# Altium schematic sheet ECONTROLmini.SchDoc(ECONTROLmini)
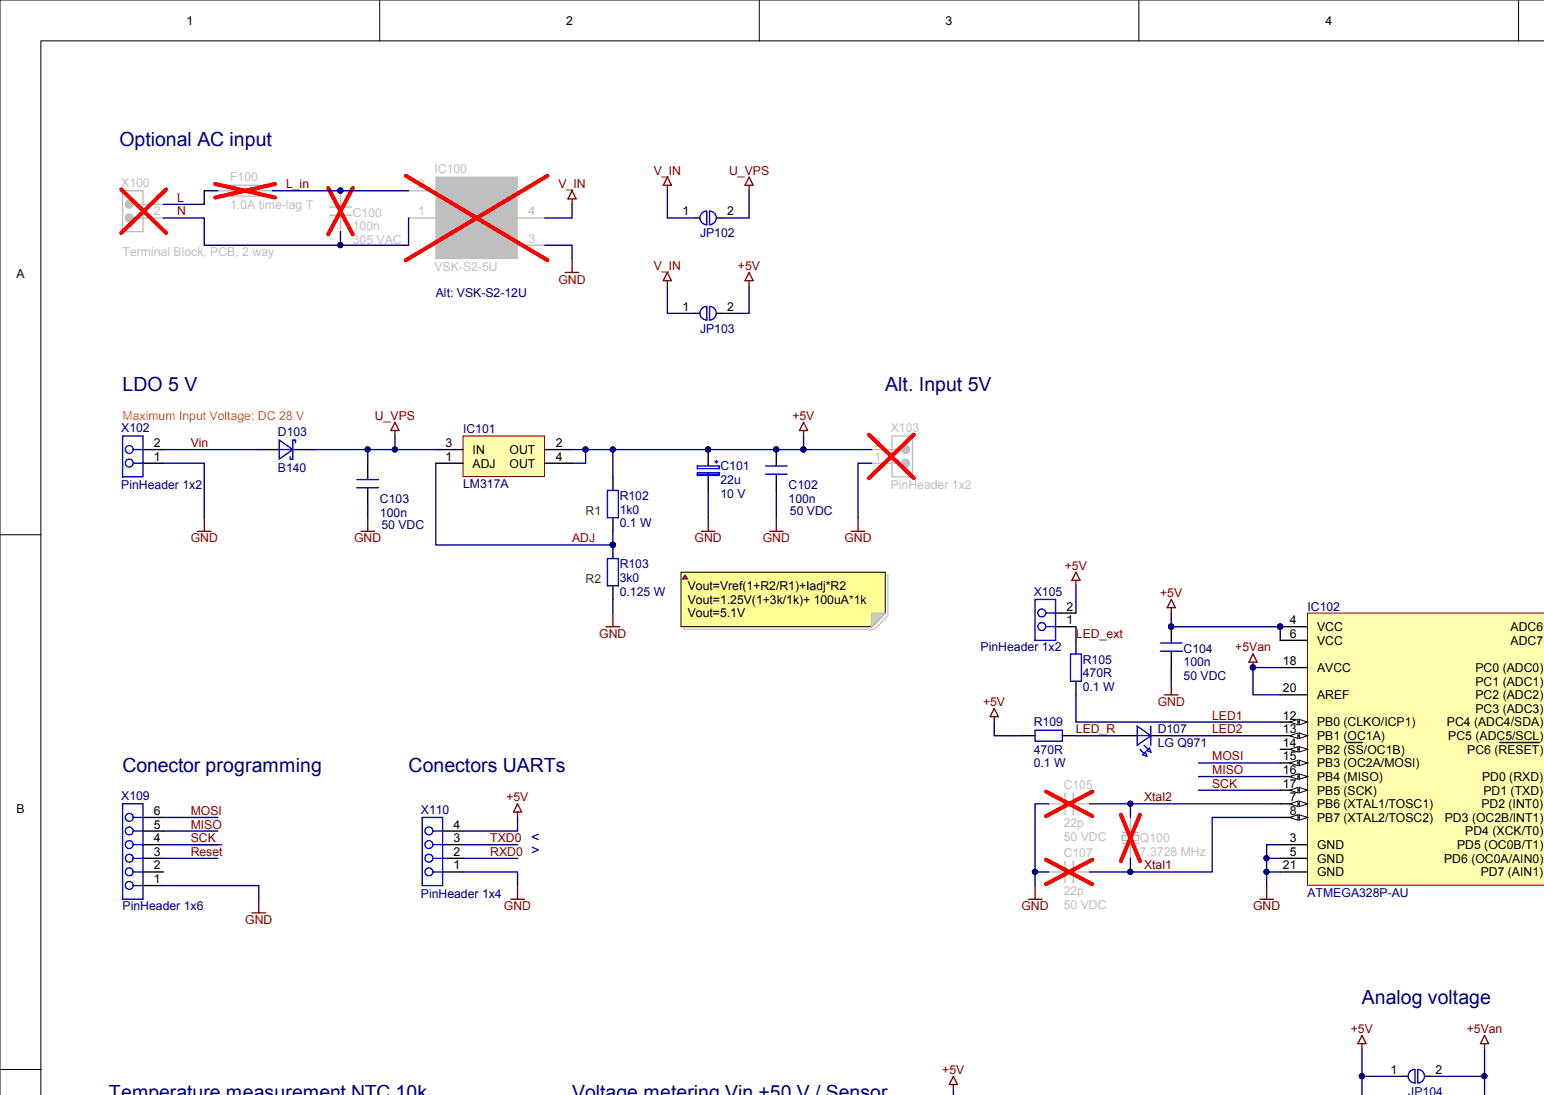
[diagram p0: ECONTROLmini.SchDoc, top left]
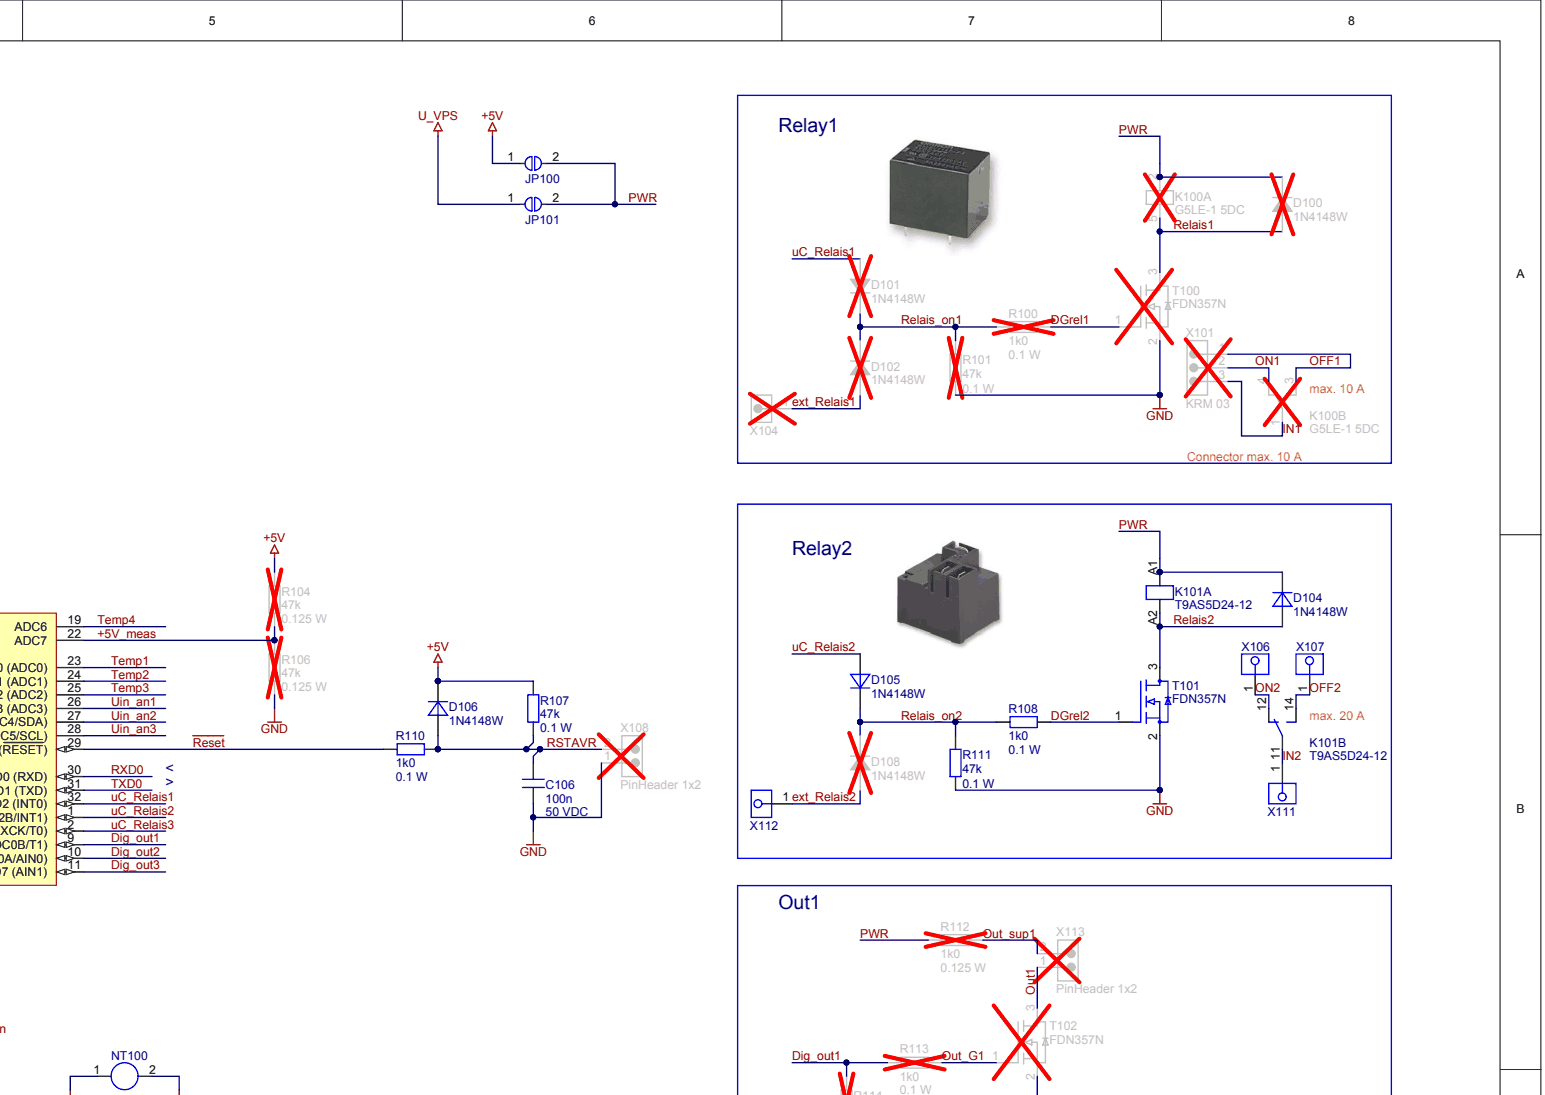
[diagram p1: ECONTROLmini.SchDoc, top right]
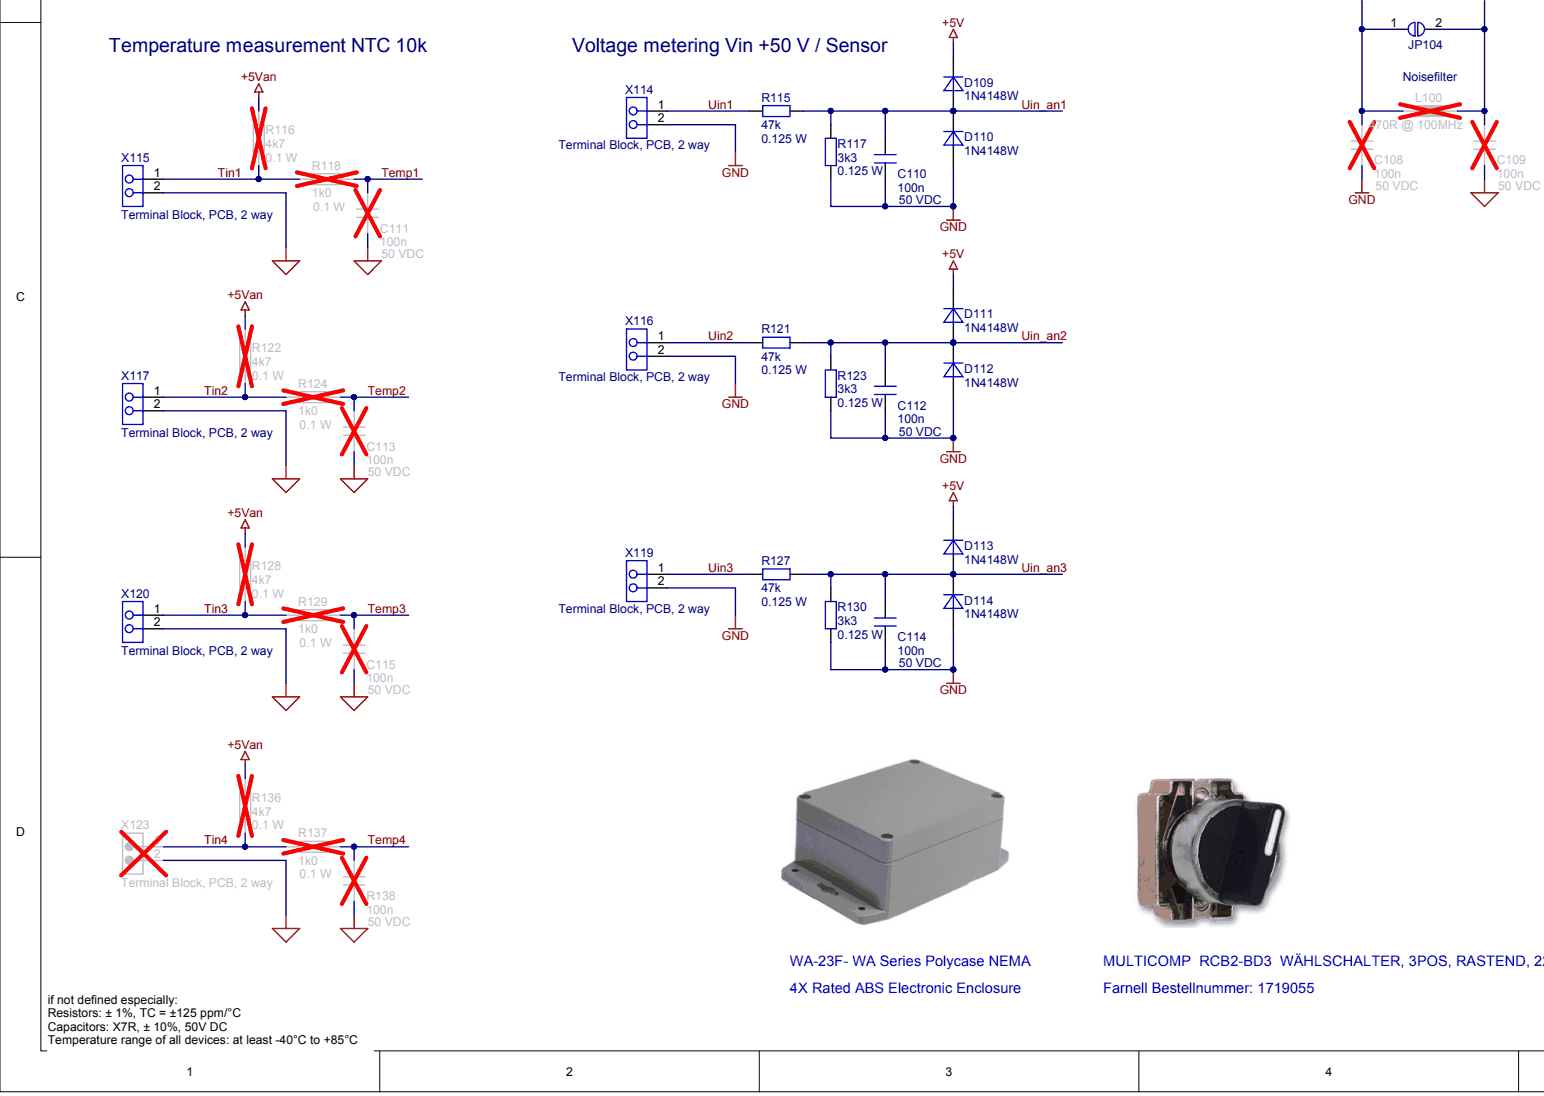
[diagram p2: ECONTROLmini.SchDoc, bottom left]
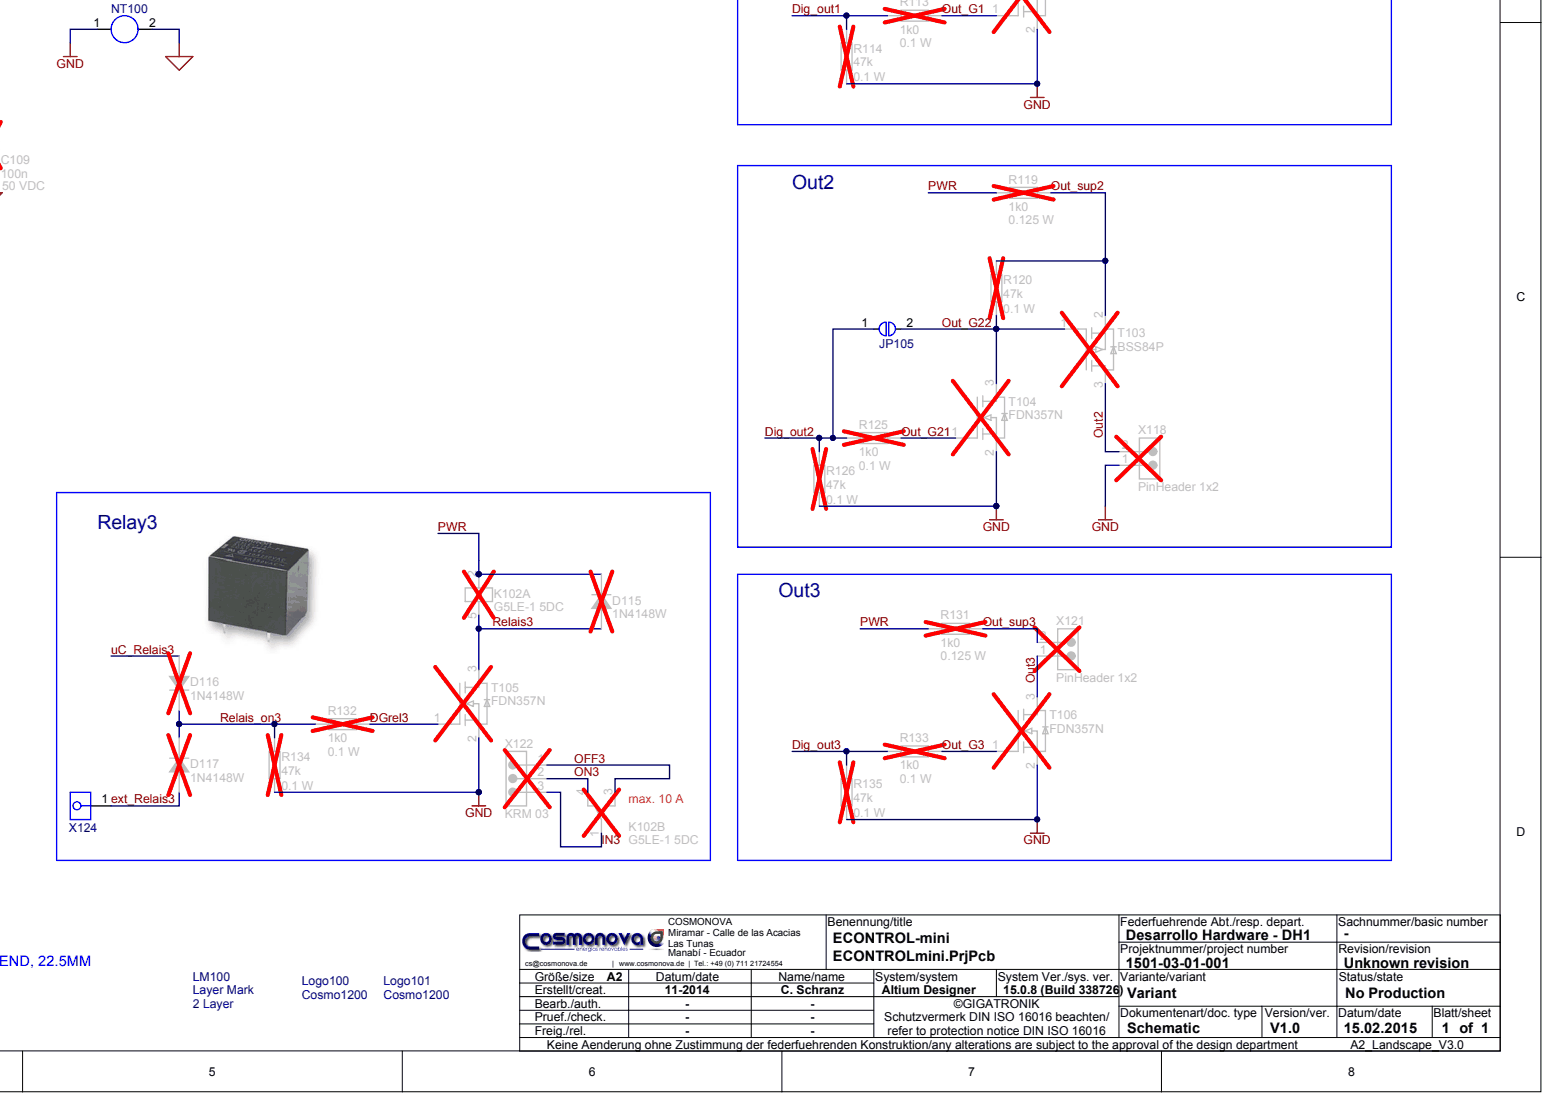
[diagram p3: ECONTROLmini.SchDoc, bottom right]

## Parts
C100  100n  [Capacitor_Epcos_MKP_B32922]
C101  22u  Voltage: 10 V  [CSMB]
C102  100n  Voltage: 50 VDC  [C0603]
C103  100n  Voltage: 50 VDC  [C0603]
C104  100n  Voltage: 50 VDC  [C0603]
C105  22p  [C0603]
C106  100n  Voltage: 50 VDC  [C0603]
C107  22p  Voltage: 50 VDC  [C0603]
C108  100n  Voltage: 50 VDC  [C0603]
C109  100n  Voltage: 50 VDC  [C0603]
C110  100n  Voltage: 50 VDC  [C0603]
C111  100n  Voltage: 50 VDC  [C0603]
C112  100n  Voltage: 50 VDC  [C0603]
C113  100n  Voltage: 50 VDC  [C0603]
C114  100n  Voltage: 50 VDC  [C0603]
C115  100n  Voltage: 50 VDC  [C0603]
D100  1N4148W  [SOD-123]
D101  1N4148W  [SOD-123]
D102  1N4148W  [SOD-123]
D103  B140  [SMA_DO-214AC]
D104  1N4148W  [SOD-123]
D105  1N4148W  [SOD-123]
D106  1N4148W  [SOD-123]
D107  LG Q971  [LED0603G]
D108  1N4148W  [SOD-123]
D109  1N4148W  [SOD-123]
D110  1N4148W  [SOD-123]
D111  1N4148W  [SOD-123]
D112  1N4148W  [SOD-123]
D113  1N4148W  [SOD-123]
D114  1N4148W  [SOD-123]
D115  1N4148W  [SOD-123]
D116  1N4148W  [SOD-123]
D117  1N4148W  [SOD-123]
F100  1.0A time-lag T  [Fuse_5x20]
IC100  VSK-S2-5U  [CUIInc_VSK-S2]
IC101  LM317A  [SOT-223]
IC102  ATMEGA328P-AU  [TQFP-32_0.8mm]
JP100  Solder Jumper  [Jumper_solder_x2_2mm]  (value not drawn)  (no BOM)
JP101  Solder Jumper  [Jumper_solder_x2_2mm]  (value not drawn)  (no BOM)
JP102  Solder Jumper  [Jumper_solder_x2_2mm]  (value not drawn)  (no BOM)
JP103  Solder Jumper  [Jumper_solder_x2_2mm]  (value not drawn)  (no BOM)
JP104  Solder Jumper  [Jumper_solder_x2_2mm]  (value not drawn)  (no BOM)
JP105  Solder Jumper  [Jumper_solder_x2_2mm]  (value not drawn)  (no BOM)
K100  G5LE-1 5DC  [Relay_SPDT]  (parts A,B)
K101  T9AS5D24-12  [Relay_TE_T9A]  (parts A,B)
K102  G5LE-1 5DC  [Relay_SPDT]  (parts A,B)
L100  470R @ 100MHz  [L0603]
LM100  2 Layer  [Layermarks2]  (no BOM)
Logo100  Cosmo1200  [Logo_1200]  (no BOM)
Logo101  Cosmo1200  [Logo_1200]  (no BOM)
NT100  Net Tie 2 Connections small  [NetTie2F_small]  (value not drawn)
Q100  7.3728 MHz  [HC49_SMD]
R100  1k0  Power: 0.1 W  [R0603]
R101  47k  Power: 0.1 W  [R0603]
R102  1k0  Power: 0.1 W  [R0603]
R103  3k0  Power: 0.125 W  [R0603]
R104  47k  Power: 0.125 W  [R0805]
R105  470R  Power: 0.1 W  [R0603]
R106  47k  Power: 0.125 W  [R0805]
R107  47k  Power: 0.1 W  [R0603]
R108  1k0  Power: 0.1 W  [R0603]
R109  470R  [R0603]
R110  1k0  Power: 0.1 W  [R0603]
R111  47k  Power: 0.1 W  [R0603]
R112  1k0  Power: 0.125 W  [R0805]
R113  1k0  Power: 0.1 W  [R0603]
R114  47k  Power: 0.1 W  [R0603]
R115  47k  Power: 0.125 W  [R0805]
R116  4k7  [R0603]
R117  3k3  Power: 0.125 W  [R0805]
R118  1k0  Power: 0.1 W  [R0603]
R119  1k0  Power: 0.125 W  [R0805]
R120  47k  Power: 0.1 W  [R0603]
R121  47k  Power: 0.125 W  [R0805]
R122  4k7  [R0603]
R123  3k3  Power: 0.125 W  [R0805]
R124  1k0  Power: 0.1 W  [R0603]
R125  1k0  [R0603]
R126  47k  Power: 0.1 W  [R0603]
R127  47k  Power: 0.125 W  [R0805]
R128  4k7  [R0603]
R129  1k0  Power: 0.1 W  [R0603]
R130  3k3  Power: 0.125 W  [R0805]
R131  1k0  Power: 0.125 W  [R0805]
R132  1k0  Power: 0.1 W  [R0603]
R133  1k0  Power: 0.1 W  [R0603]
R134  47k  Power: 0.1 W  [R0603]
R135  47k  Power: 0.1 W  [R0603]
R136  4k7  [R0603]
R137  1k0  Power: 0.1 W  [R0603]
R138  100n  Voltage: 50 VDC  [C0603]
T100  FDN357N  [SuperSOT-3]
T101  FDN357N  [SuperSOT-3]
T102  FDN357N  [SuperSOT-3]
T103  BSS84P  [SOT-23-3]
T104  FDN357N  [SuperSOT-3]
T105  FDN357N  [SuperSOT-3]
T106  FDN357N  [SuperSOT-3]
X100  Terminal Block, PCB, 2 way  [Connector_Lumberg_KRM-02]
X101  KRM 03  [Connector_Lumberg_KRM03]
X102  PinHeader 1x2  [Connector_PinHeader1x2_2.54]
X103  PinHeader 1x2  [Connector_PinHeader1x2_2.54]
X104  Pad THT 0.8 mm 1.6 mm  [Pad_THT_0.8mm_1.6mm]  (value not drawn)  (no BOM)
X105  PinHeader 1x2  [Connector_PinHeader1x2_2.54]
X106  Pad THT 0.8 mm 1.6 mm  [Pad_THT_0.8mm_1.6mm]  (value not drawn)  (no BOM)
X107  Pad THT 0.8 mm 1.6 mm  [Pad_THT_0.8mm_1.6mm]  (value not drawn)  (no BOM)
X108  PinHeader 1x2  [Connector_PinHeader1x2_2.54]
X109  PinHeader 1x6  [Connector_PinHeader1x6_2.54]
X110  PinHeader 1x4  [Connector_PinHeader1x4_2.54]
X111  Pad THT 0.8 mm 1.6 mm  [Pad_THT_0.8mm_1.6mm]  (value not drawn)  (no BOM)
X112  Pad THT 0.8 mm 1.6 mm  [Pad_THT_0.8mm_1.6mm]  (value not drawn)  (no BOM)
X113  PinHeader 1x2  [Connector_PinHeader1x2_2.54]
X114  Terminal Block, PCB, 2 way  [Connector_Lumberg_KRM-02]
X115  Terminal Block, PCB, 2 way  [Connector_Lumberg_KRM-02]
X116  Terminal Block, PCB, 2 way  [Connector_Lumberg_KRM-02]
X117  Terminal Block, PCB, 2 way  [Connector_Lumberg_KRM-02]
X118  PinHeader 1x2  [Connector_PinHeader1x2_2.54]
X119  Terminal Block, PCB, 2 way  [Connector_Lumberg_KRM-02]
X120  Terminal Block, PCB, 2 way  [Connector_Lumberg_KRM-02]
X121  PinHeader 1x2  [Connector_PinHeader1x2_2.54]
X122  KRM 03  [Connector_Lumberg_KRM03]
X123  Terminal Block, PCB, 2 way  [Connector_Lumberg_KRM-02]
X124  Pad THT 0.8 mm 1.6 mm  [Pad_THT_0.8mm_1.6mm]  (value not drawn)  (no BOM)

## Nets
+5V: C101.P C102.2 C104.2 C108.2 D106.C D109.C D111.C D113.C IC101.2(OUT) IC101.4(OUT) IC102.4(VCC) IC102.6(VCC) JP100.1 JP103.2 JP104.1 L100.1 R102.2 R104.1 R107.2 R109.2 X103.2 X105.2 X110.4
+5VAN: C109.2 IC102.18(AVCC) IC102.20(AREF) JP104.2 L100.2 R116.2 R122.2 R128.2 R136.2
AGND: C109.1 C111.1 C113.1 C115.1 NT100.2 R138.1 X115.2 X117.2 X120.2 X123.2
GND: C101.N C102.1 C103.1 C104.1 C105.1 C106.1 C107.1 C108.1 C110.1 C112.1 C114.1 D110.A D112.A D114.A IC100.3(-V) IC102.3(GND) IC102.5(GND) IC102.21(GND) NT100.1 R101.1 R103.1 R106.2 R111.1 R114.1 R117.1 R123.1 R126.1 R130.1 R134.1 R135.1 T100.2 T101.2 T102.2 T104.2 T105.2 T106.2 X102.1 X103.1 X108.1 X109.1 X110.1 X114.2 X116.2 X118.1 X119.2
U_VPS: C103.2 D103.C IC101.3(IN) JP101.1 JP102.2
V_IN: IC100.4(+V) JP102.1 JP103.1
+5V_MEAS: IC102.22(ADC7) R104.2 R106.1
ADJ: IC101.1(ADJ) R102.1 R103.2
DGREL1: R100.1 T100.1
DGREL2: R108.1 T101.1
DGREL3: R132.1 T105.1
DIG_OUT1: IC102.9(PD5_(OC0B/T1)) R113.2 R114.2
DIG_OUT2: IC102.10(PD6_(OC0A/AIN0)) JP105.1 R125.2 R126.2
DIG_OUT3: IC102.11(PD7_(AIN1)) R133.2 R135.2
EXT_RELAIS1: D102.A X104.1
EXT_RELAIS2: D108.A X112.1
EXT_RELAIS3: D117.A X124.1
IN1: K100.1 X101.3
IN2: K101.11 X111.1
IN3: K102.1 X122.3
L: F100.1 X100.1
L_IN: C100.2 F100.2 IC100.2(L)
LED1: IC102.12(PB0_(CLKO/ICP1)) R105.1
LED2: D107.C IC102.13(PB1_(OC1A))
LED_EXT: R105.2 X105.1
LED_R: D107.A R109.1
MISO: IC102.16(PB4_(MISO)) X109.5
MOSI: IC102.15(PB3_(OC2A/MOSI)) X109.6
N: C100.1 IC100.1(N) X100.2
OFF1: K100.3 X101.1
OFF2: K101.14 X107.1
OFF3: K102.3 X122.1
ON1: K100.4 X101.2
ON2: K101.12 X106.1
ON3: K102.4 X122.2
OUT1: T102.3 X113.1
OUT2: T103.3 X118.2
OUT3: T106.3 X121.1
OUT_G1: R113.1 T102.1
OUT_G3: R133.1 T106.1
OUT_G21: R125.1 T104.1
OUT_G22: JP105.2 R120.1 T103.1 T104.3
OUT_SUP1: R112.2 X113.2
OUT_SUP2: R119.2 R120.2 T103.2
OUT_SUP3: R131.2 X121.2
PWR: D100.C D104.C D115.C JP100.2 JP101.2 K100.2 K101.A1 K102.2 R112.1 R119.1 R131.1
~{RESET}: IC102.29(PC6_(RESET)) R110.1 X109.3
RELAIS1: D100.A K100.5 T100.3
RELAIS2: D104.A K101.A2 T101.3
RELAIS3: D115.A K102.5 T105.3
RELAIS_ON1: D101.C D102.C R100.2 R101.2
RELAIS_ON2: D105.C D108.C R108.2 R111.2
RELAIS_ON3: D116.C D117.C R132.2 R134.2
RSTAVR: C106.2 D106.A R107.1 R110.2 X108.2
RXD0: IC102.30(PD0_(RXD)) X110.2
SCK: IC102.17(PB5_(SCK)) X109.4
TEMP1: C111.2 IC102.23(PC0_(ADC0)) R118.2
TEMP2: C113.2 IC102.24(PC1_(ADC1)) R124.2
TEMP3: C115.2 IC102.25(PC2_(ADC2)) R129.2
TEMP4: IC102.19(ADC6) R137.2 R138.2
TIN1: R116.1 R118.1 X115.1
TIN2: R122.1 R124.1 X117.1
TIN3: R128.1 R129.1 X120.1
TIN4: R136.1 R137.1 X123.1
TXD0: IC102.31(PD1_(TXD)) X110.3
UC_RELAIS1: D101.A IC102.32(PD2_(INT0))
UC_RELAIS2: D105.A IC102.1(PD3_(OC2B/INT1))
UC_RELAIS3: D116.A IC102.2(PD4_(XCK/T0))
UIN1: R115.2 X114.1
UIN2: R121.2 X116.1
UIN3: R127.2 X119.1
UIN_AN1: C110.2 D109.A D110.C IC102.26(PC3_(ADC3)) R115.1 R117.2
UIN_AN2: C112.2 D111.A D112.C IC102.27(PC4_(ADC4/SDA)) R121.1 R123.2
UIN_AN3: C114.2 D113.A D114.C IC102.28(PC5_(ADC5/SCL)) R127.1 R130.2
VIN: D103.A X102.2
XTAL1: C107.2 IC102.8(PB7_(XTAL2/TOSC2)) Q100.1
XTAL2: C105.2 IC102.7(PB6_(XTAL1/TOSC1)) Q100.2

Not connected: IC102 14(PB2_(SS/OC1B)); X109 2

## Library data of the parts
C100 = 6093: Film Capacitors EMI Suppression Capacitors (MKP); manufacturer: Epcos; manufacturer_pn: B32922C3104K189; Database Table Name: altium.capacitors; state: checked; temperaturerange: -40 °C to +110 °C; tolerance: ±10 %; Voltage: 305 VAC; Component Kind: Standard; componentlink1description: Datasheet; componentlink1url: \\fs1\GT-Daten\Datenbanken\AltiumDesigner\Library\Datasheets\Epcos\EPCOS_EMI_MKP.pdf; designator: C; distributor1link: http://de.farnell.com/epcos/b32922c3104k189/folienkondensator-0-1uf-305vac/dp/2315560; distributor1name: Farnell; distributor1orderno: 2315560; id: 6093; manufacturerlink: http://www.epcos.de; type: -; Library Name: Altium Postgres.SVNDbLib
C101 = 668: Tantalum Capacitor; manufacturer: Kemet; Database Table Name: altium.capacitors; state: checked; temperaturerange: -55 °C to +125 °C; tolerance: ±20 %; type: Tantal; Component Kind: Standard; componentlink1description: Datasheet; componentlink1url: \\fs1\GT-Daten\Datenbanken\AltiumDesigner\Library\Datasheets\Capacitors\T491.pdf; designator: C; distributor1name: Bürklin; distributor1orderno: 25 D 3348; id: 668; manufacturerlink: http://www.kemet.com/; Library Name: Altium Postgres.SVNDbLib
C102-C104 C106 C108-C115 = 43: SMD C0603; manufacturer: Kemet; Database Table Name: altium.capacitors; state: approved; temperaturerange: ±15% (-55 °C to +125 °C); tolerance: ±10 %; type: X7R; Component Kind: Standard; componentlink1description: Datasheet; componentlink1url: \\fs1\GT-Daten\Datenbanken\AltiumDesigner\Library\Datasheets\Capacitors\Kemet_CeramicSMD.pdf; designator: C; distributor1name: Bürklin; distributor1orderno: 53 D 2071; distributor2name: Rutronik; distributor2orderno: KKK16043; id: 43; manufacturerlink: http://www.kemet.com/; Library Name: Altium Postgres.SVNDbLib
C105 = 17: SMD C0603; manufacturer: Kemet; Database Table Name: altium.capacitors; state: approved; temperaturerange: ±30 PPM/°C; tolerance: ±5 %; type: NP0; Voltage: 50 VDC; Component Kind: Standard; componentlink1description: Datasheet; componentlink1url: \\fs1\GT-Daten\Datenbanken\AltiumDesigner\Library\Datasheets\Capacitors\Kemet_CeramicSMD.pdf; designator: C; distributor1name: Bürklin; distributor1orderno: 53 D 2006; distributor2name: Rutronik; distributor2orderno: KKS2130; id: 17; manufacturerlink: http://www.kemet.com/; Library Name: Altium Postgres.SVNDbLib
C107 = 17: SMD C0603; manufacturer: Kemet; Database Table Name: altium.capacitors; state: approved; temperaturerange: ±30 PPM/°C; tolerance: ±5 %; type: NP0; Component Kind: Standard; componentlink1description: Datasheet; componentlink1url: \\fs1\GT-Daten\Datenbanken\AltiumDesigner\Library\Datasheets\Capacitors\Kemet_CeramicSMD.pdf; designator: C; distributor1name: Bürklin; distributor1orderno: 53 D 2006; distributor2name: Rutronik; distributor2orderno: KKS2130; id: 17; manufacturerlink: http://www.kemet.com/; Library Name: Altium Postgres.SVNDbLib
D100-D102 D104-D106 D108-D117 = 1003: Fast switching diode; manufacturer: Diodes Zetex; manufacturer_pn: 1N4148W-7-F; Database Table Name: altium.diode_rf_smallsignal; if: 150 mA; powerdissipation: 400 mW; state: approved; temperaturerange: -65 °C to +150 °C; type: RF/Small signal; vf: 1.0 V @ 25 °C , If = 50 mA; Component Kind: Standard; componentlink1description: Datasheet; componentlink1url: \\fs1\GT-Daten\Datenbanken\AltiumDesigner\Library\Datasheets\Diode\1N4148W.pdf; designator: D; distributor1name: Farnell; distributor1orderno: 1776392; distributor2link: http://www.digikey.com/product-detail/en/1N4148W-7-F/1N4148W-FDICT-ND/815280; distributor2name: Digikey; distributor2orderno: 1N4148W-FDICT-ND; id: 1003; manufacturerlink: http://www.diodes.com/; Library Name: Altium Postgres.SVNDbLib
D103 = 4210: 1.0A SURFACE MOUNT SCHOTTKY BARRIER RECTIFIER; manufacturer: Diodes Inc; manufacturer_pn: B120/B - B160/B; Database Table Name: altium.diode_schottky; state: approved; temperaturerange: -65 °C to +150 °C; vf: 500 mV @ 25 °C , If = 1.0 A; Component Kind: Standard; componentlink1description: Datasheet; componentlink1url: \\fs1\GT-Daten\Datenbanken\AltiumDesigner\Library\Datasheets\Diode\B1x0B.pdf; designator: D; distributor1link: http://de.farnell.com/diodes-inc/b140-13-f/diode-schottky-40v-1a-sma/dp/1843670; distributor1name: Farnell; distributor1orderno: 1843670; id: 4210; if: 1.0 A; manufacturerlink: http://www.diodes.com/; powerdissipation: -; type: Schottky; Library Name: Altium Postgres.SVNDbLib
D107 = 1030: LED 0603; manufacturer: OSRAM; color: green; Database Table Name: altium.diode_led; forwardcurrent: 25 mA; forwardvoltage: 2.2 V; state: approved; temperaturerange: -30 °C to +85 °C; Component Kind: Standard; componentlink1description: Datasheet; componentlink1url: \\fs1\GT-Daten\Datenbanken\AltiumDesigner\Library\Datasheets\Diode\Osram LED LG Q971.pdf; designator: D; distributor1link: http://de.farnell.com/osram/lgq971/led-smd-grun/dp/1226372?Ntt=1226372; distributor1name: Farnell; distributor1orderno: 1226372; distributor2name: RS; distributor2orderno: 654-5767; distributor3link: https://www.distrelec.de/ishopWebFront/search/luceneSearch.do?dispatch=find&keywordPhrase=251958; distributor3name: Distrelec; distributor3orderno: 251958; id: 1030; manufacturer_pn: LG Q971; manufacturerlink: http://www.osram.de; powerdissipation: -; type: LED; Library Name: Altium Postgres.SVNDbLib
F100 = 6092: Surface Mount Fuses Type SMD-FST 5x20 1.0A 250V AC; manufacturer: Schurter; manufacturer_pn: 0034.5617.11; Database Table Name: altium.fuse; state: checked; temperaturerange: -55 °C to +125 °C; voltage: 250 VAC; Component Kind: Standard; componentlink1description: Datasheet; componentlink1url: \\fs1\GT-Daten\Datenbanken\AltiumDesigner\Library\Datasheets\Fuse\Schurter_PCB_SMD-FST.pdf; current: 1.0 A; designator: F; distributor1link: http://de.farnell.com/schurter/0034-5617-11/sicherung-smd-5x20mm-t-1-0a/dp/4448080; distributor1name: Farnell; distributor1orderno: 4448080; id: 6092; manufacturerlink: http://www.schurter.de/; Library Name: Altium Postgres.SVNDbLib
IC100 = 6091: AC-DC POWER SUPPLY 5V 400mA; manufacturer: CUIInc; Database Table Name: altium.ic; state: checked; temperaturerange: -25 °C to +70 °C; Component Kind: Standard; componentlink1description: Datasheet; componentlink1url: \\fs1\GT-Daten\Datenbanken\AltiumDesigner\Library\Datasheets\CUIInc\vsk-s2.pdf; designator: IC; distributor1link: http://www.digikey.de/product-detail/de/VSK-S2-5U/102-2589-ND/3316493; distributor1name: Digikey; distributor1orderno: 102-2589-ND; id: 6091; manufacturer_pn: VSK-S2-5U; manufacturerlink: http://www.cui.com/; Library Name: Altium Postgres.SVNDbLib
IC101 = 873: 3-Terminal Adjustable Regulator; manufacturer: National Semiconductor; manufacturer_pn: LM317AEMP; Database Table Name: altium.ic; state: checked; temperaturerange: -40 °C to +125 °C; Component Kind: Standard; componentlink1description: Datasheet; componentlink1url: \\fs1\GT-Daten\Datenbanken\AltiumDesigner\Library\Datasheets\National\LM317.pdf; designator: IC; distributor1name: Farnell; distributor1orderno: 1469084; distributor2link: https://www.distrelec.de/ishopWebFront/search/luceneSearch.do?dispatch=find&keywordPhrase=663093; distributor2name: Distrelec; distributor2orderno: 663093; id: 873; manufacturerlink: http://www.national.com; Library Name: Altium Postgres.SVNDbLib
IC102 = 6063: MCU 8BIT, AVR, 32K FLASH, 32TQFP; manufacturer: Atmel; Database Table Name: altium.ic; state: checked; temperaturerange: -40 °C to +85 °C; Component Kind: Standard; componentlink1description: Datasheet; componentlink1url: \\fs1\GT-Daten\Datenbanken\AltiumDesigner\Library\Datasheets\Atmel\ATMEGA328.pdf; designator: IC; distributor1link: http://de.farnell.com/atmel/atmega328p-au/mcu-8bit-avr-32k-flash-32tqfp/dp/1715486; distributor1name: Farnell; distributor1orderno: 1715486; id: 6063; manufacturer_pn: ATMEGA328P-AU; manufacturerlink: http://www.atmel.com/default.aspx; Library Name: Altium Postgres.SVNDbLib
JP100-JP105 = 4367: ATTENTION: Due to local design-rule exeptions routing from outside into jumper-pad is impossible. Please start routing from inside jumper pad.; Database Table Name: altium.jumper; Rule: UNIONINDEX{}0[]RULEKIND{}ShortCircuit[]NETSCOPE{}DifferentNets[]LAYERKIND{}SameLayer[]NAME{}Schematic Short-Circuit Constraint[]ALLOWED{}TRUE[]UNIT{}Imperial[]PcbType{}ProtelPcb; state: checked; designator: JP; id: 4367; manufacturer: -; manufacturer_pn: -; manufacturerlink: -; temperaturerange: -; Library Name: Altium Postgres.SVNDbLib
K100 K102 = 6069: Cubic, Single-pole 10-A Power Relay, PCB; manufacturer: Omron; Database Table Name: altium.relais; state: checked; temperaturerange: -40 °C to +85 °C; Component Kind: Standard; componentlink1description: Datasheet; componentlink1url: \\fs1\GT-Daten\Datenbanken\AltiumDesigner\Library\Datasheets\Relais\Omron_G5LE-x.pdf; designator: K; distributor1link: http://de.farnell.com/omron-electronic-components/g5le-1-5dc/pcb-leistungsrelais/dp/9949887?ost=G5LE-1+5DC; distributor1name: Farnell; distributor1orderno: 9949887; id: 6069; manufacturer_pn: G5LE-1 5DC; manufacturerlink: http://www.omron.com/; Library Name: Altium Postgres.SVNDbLib
K101 = 5952: DC Coil PC Board or Panel Mount Relay, Pinning NOT CHECKED!!!; manufacturer: Tyco Electronics; Database Table Name: altium.relais; temperaturerange: -55 °C to +85 °C; Component Kind: Standard; componentlink1description: Datasheet; componentlink1url: \\fs1\GT-Daten\Datenbanken\AltiumDesigner\Library\Datasheets\Tyco\Tyco_T9A_series.pdf; designator: K; distributor1link: http://de.farnell.com/te-connectivity/t9as5d24-12/leistungsrelais-flachsteckans-12vdc/dp/1629071; distributor1name: Farnell; distributor1orderno: 1629071; id: 5952; manufacturer_pn: T9AS5D24-12; manufacturerlink: http://www.te.com/de/home.html; state: checked; Library Name: Altium Postgres.SVNDbLib
L100 = 139: Chip Ferrit SMD, 200 mA, 470 Ohm @ 100 MHz; manufacturer: Murata; manufacturer_pn: BLM18AG471SH1D; Database Table Name: altium.inductors; state: approved; temperaturerange: -55 °C to +125 °C; Component Kind: Standard; componentlink1description: Datasheet; componentlink1url: \\fs1\GT-Daten\Datenbanken\AltiumDesigner\Library\Datasheets\Inductors\blm18a.pdf; designator: L; distributor1name: Farnell; distributor1orderno: 1515677; id: 139; manufacturerlink: http://www.murata.com/; Library Name: Altium Postgres.SVNDbLib
LM100 = 1133: Layer Mark; manufacturer: Gigatronik; Database Table Name: altium.layermark; state: checked; designator: LM; id: 1133; manufacturerlink: http://www.gigatronik.com/; temperaturerange: -; Library Name: Altium Postgres.SVNDbLib
Logo100 Logo101 = Logo_1200: Cosmonova Logo; Library Name: Cosmonova.IntLib
NT100 = 1227: manufacturer: Gigatronik; Database Table Name: altium.nettie; state: checked; Component Kind: Net Tie; Description: Net Tie 2 Connections small; designator: NT; id: 1227; manufacturerlink: http://www.gigatronik.com/; temperaturerange: -; Library Name: Altium Postgres.SVNDbLib
Q100 = 1245: Quartz Crystal, SMD; manufacturer: AKER; manufacturer_pn: CAA-7.3728-18-3050-X; Database Table Name: altium.crystals; state: checked; temperaturerange: -20 °C to +70 °C; Component Kind: Standard; componentlink1description: Datasheet; componentlink1url: \\fs1\GT-Daten\Datenbanken\AltiumDesigner\Library\Datasheets\Crystals\Crystal CAA Serie.pdf; designator: Q; distributor1name: RS; distributor1orderno: 671-9249; id: 1245; manufacturerlink: http://www.aker.com.tw; Library Name: Altium Postgres.SVNDbLib
R100 R102 R108 R110 R113 R118 R124 R129 R132 R133 R137 = 302: SMD R0603; manufacturer: Yageo; Database Table Name: altium.resistors; state: approved; temperaturerange: -55 °C to +155 °C; tolerance: ±1 %; voltage: 50 V; Component Kind: Standard; componentlink1description: Datasheet; componentlink1url: \\fs1\GT-Daten\Datenbanken\AltiumDesigner\Library\Datasheets\Resistors\YAGEO_RC0603.pdf; designator: R; distributor1name: Bürklin; distributor1orderno: 26 E 792; id: 302; manufacturer_pn: 1k0; manufacturerlink: http://www.yageo.com/portal/index.jsp; Library Name: Altium Postgres.SVNDbLib
R101 R107 R111 R114 R120 R126 R134 R135 = 322: SMD R0603; manufacturer: Yageo; Database Table Name: altium.resistors; state: approved; temperaturerange: -55 °C to +155 °C; tolerance: ±1 %; voltage: 50 V; Component Kind: Standard; componentlink1description: Datasheet; componentlink1url: \\fs1\GT-Daten\Datenbanken\AltiumDesigner\Library\Datasheets\Resistors\YAGEO_RC0603.pdf; designator: R; distributor1name: Bürklin; distributor1orderno: 26 E 832; id: 322; manufacturer_pn: 47k; manufacturerlink: http://www.yageo.com/portal/index.jsp; Library Name: Altium Postgres.SVNDbLib
R103 = 4472: SMD R0603; manufacturer: KOA; manufacturer_pn: SG73P1JTTD3001F; Database Table Name: altium.resistors; state: approved; temperaturerange: -55 °C to +155 °C; tolerance: ±1 %; voltage: 75 V; Component Kind: Standard; componentlink1description: Datasheet; componentlink1url: \\fs1\GT-Daten\Datenbanken\AltiumDesigner\Library\Datasheets\Resistors\KOA_Resistor_SMD_0603.pdf; designator: R; distributor1link: http://de.farnell.com/koa/sg73p1jttd3001f/; distributor1name: Farnell; distributor1orderno: 1399924RL; id: 4472; manufacturerlink: http://www.koaspeer.com/; Library Name: Altium Postgres.SVNDbLib
R104 R106 R115 R121 R127 = 442: SMD R0805; manufacturer: Yageo; Database Table Name: altium.resistors; state: checked; temperaturerange: -55 °C to +155 °C; tolerance: ±1 %; voltage: 150 V; Component Kind: Standard; componentlink1description: Datasheet; componentlink1url: \\fs1\GT-Daten\Datenbanken\AltiumDesigner\Library\Datasheets\Resistors\YAGEO_RC0805.pdf; designator: R; distributor1name: Bürklin; distributor1orderno: 11 E 274; id: 442; manufacturer_pn: 47k; manufacturerlink: http://www.yageo.com/portal/index.jsp; Library Name: Altium Postgres.SVNDbLib
R105 = 298: SMD R0603; manufacturer: Yageo; Database Table Name: altium.resistors; state: approved; temperaturerange: -55 °C to +155 °C; tolerance: ±1 %; voltage: 50 V; Component Kind: Standard; componentlink1description: Datasheet; componentlink1url: \\fs1\GT-Daten\Datenbanken\AltiumDesigner\Library\Datasheets\Resistors\YAGEO_RC0603.pdf; designator: R; distributor1name: Bürklin; distributor1orderno: 26 E 784; id: 298; manufacturer_pn: 470R; manufacturerlink: http://www.yageo.com/portal/index.jsp; Library Name: Altium Postgres.SVNDbLib
R109 = 298: SMD R0603; manufacturer: Yageo; Database Table Name: altium.resistors; Power: 0.1 W; state: approved; temperaturerange: -55 °C to +155 °C; tolerance: ±1 %; voltage: 50 V; Component Kind: Standard; componentlink1description: Datasheet; componentlink1url: \\fs1\GT-Daten\Datenbanken\AltiumDesigner\Library\Datasheets\Resistors\YAGEO_RC0603.pdf; designator: R; distributor1name: Bürklin; distributor1orderno: 26 E 784; id: 298; manufacturer_pn: 470R; manufacturerlink: http://www.yageo.com/portal/index.jsp; Library Name: Altium Postgres.SVNDbLib
R112 R119 R131 = 402: SMD R0805; manufacturer: Yageo; manufacturer_pn: RC0805FR-071KL; Database Table Name: altium.resistors; state: approved; temperaturerange: -55 °C to +155 °C; tolerance: ±1 %; voltage: 150 V; Component Kind: Standard; componentlink1description: Datasheet; componentlink1url: \\fs1\GT-Daten\Datenbanken\AltiumDesigner\Library\Datasheets\Resistors\YAGEO_RC0805.pdf; designator: R; distributor1name: Bürklin; distributor1orderno: 11 E 194; id: 402; manufacturerlink: http://www.yageo.com/portal/index.jsp; Library Name: Altium Postgres.SVNDbLib
R116 R122 R128 R136 = 310: SMD R0603; manufacturer: Yageo; Database Table Name: altium.resistors; Power: 0.1 W; state: approved; temperaturerange: -55 °C to +155 °C; tolerance: ±1 %; voltage: 50 V; Component Kind: Standard; componentlink1description: Datasheet; componentlink1url: \\fs1\GT-Daten\Datenbanken\AltiumDesigner\Library\Datasheets\Resistors\YAGEO_RC0603.pdf; designator: R; distributor1name: Bürklin; distributor1orderno: 26 E 808; id: 310; manufacturer_pn: 4k7; manufacturerlink: http://www.yageo.com/portal/index.jsp; Library Name: Altium Postgres.SVNDbLib
R117 R123 R130 = 414: SMD R0805; manufacturer: Yageo; Database Table Name: altium.resistors; state: approved; temperaturerange: -55 °C to +155 °C; tolerance: ±1 %; voltage: 150 V; Component Kind: Standard; componentlink1description: Datasheet; componentlink1url: \\fs1\GT-Daten\Datenbanken\AltiumDesigner\Library\Datasheets\Resistors\YAGEO_RC0805.pdf; designator: R; distributor1name: Bürklin; distributor1orderno: 11 E 218; id: 414; manufacturer_pn: 3k3; manufacturerlink: http://www.yageo.com/portal/index.jsp; Library Name: Altium Postgres.SVNDbLib
R125 = 302: SMD R0603; manufacturer: Yageo; Database Table Name: altium.resistors; Power: 0.1 W; state: approved; temperaturerange: -55 °C to +155 °C; tolerance: ±1 %; voltage: 50 V; Component Kind: Standard; componentlink1description: Datasheet; componentlink1url: \\fs1\GT-Daten\Datenbanken\AltiumDesigner\Library\Datasheets\Resistors\YAGEO_RC0603.pdf; designator: R; distributor1name: Bürklin; distributor1orderno: 26 E 792; id: 302; manufacturer_pn: 1k0; manufacturerlink: http://www.yageo.com/portal/index.jsp; Library Name: Altium Postgres.SVNDbLib
R138 = 43: SMD C0603; manufacturer: Kemet; Database Table Name: altium.capacitors; state: approved; temperaturerange: ±15% (-55 °C to +125 °C); tolerance: ±10 %; Component Kind: Standard; componentlink1description: Datasheet; componentlink1url: \\fs1\GT-Daten\Datenbanken\AltiumDesigner\Library\Datasheets\Capacitors\Kemet_CeramicSMD.pdf; designator: C; distributor1name: Bürklin; distributor1orderno: 53 D 2071; distributor2name: Rutronik; distributor2orderno: KKK16043; id: 43; manufacturerlink: http://www.kemet.com/; type: X7R; Library Name: Altium Postgres.SVNDbLib
T100-T102 T104-T106 = 5249: N-Channel Logic Level Enhancement Mode Field Effect Transistor; manufacturer: Fairchild Semiconductor; current: 1.9 A; Database Table Name: altium.mosfets; power: 0.46 W; rds: 90 mOhm; state: checked; temperaturerange: -55 °C to +150 °C; type: mosfet-n; voltage: 30 V; Component Kind: Standard; componentlink1description: Datasheet; componentlink1url: \\fs1\GT-Daten\Datenbanken\AltiumDesigner\Library\Datasheets\Fairchild\FDN357N.pdf; designator: T; distributor1link: http://de.farnell.com/fairchild-semiconductor/fdn337n/mosfet-n-sot-23/dp/9845356?Ntt=9845356; distributor1name: Farnell; distributor1orderno: 9845356; distributor2link: http://de.mouser.com/Search/ProductDetail.aspx?qs=HK%252beIG1iaajfnM7yzgS5mQ%3D%3D; distributor2name: Mouser; distributor2orderno: 512-FDN357N; id: 5249; manufacturer_pn: FDN357N; manufacturerlink: http://www.fairchildsemi.com/; Library Name: Altium Postgres.SVNDbLib
T103 = 4448: P-Kanal-Mosfet; manufacturer: Infineon; current: -170 mA; Database Table Name: altium.mosfets; power: 0.36 W; rds: 8 Ohm; state: approved; temperaturerange: -55 °C to +150 °C; type: mosfet-p; voltage: -60 V; Component Kind: Standard; componentlink1description: Datasheet; componentlink1url: \\fs1\GT-Daten\Datenbanken\AltiumDesigner\Library\Datasheets\Transistors\BSS84P.pdf; designator: T; distributor1link: http://de.farnell.com/infineon/bss84p/mosfet-p-sot-23/dp/1056526; distributor1name: Farnell; distributor1orderno: 1056526; id: 4448; manufacturer_pn: BSS84P; manufacturerlink: http://www.infineon.com; Library Name: Altium Postgres.SVNDbLib
X100 X114-X117 X119 X120 X123 = 4027: TERMINAL BLOCK, PCB, 2WAY; manufacturer: Lumberg; manufacturer_pn: KRM 02; Database Table Name: altium.connector; state: approved; temperaturerange: -25 °C to +100 °C; Component Kind: Standard; componentlink1description: Datasheet; componentlink1url: \\fs1\GT-Daten\Datenbanken\AltiumDesigner\Library\Datasheets\Connectors\Lumberg_Schraubanschlussklemme.pdf; designator: X; distributor1link: http://de.farnell.com/lumberg/krm-02/klemme-leiterpl-polyamid-2pol-10mm/dp/1177875; distributor1name: Farnell; distributor1orderno: 1177875; id: 4027; manufacturerlink: http://www.lumberg.com; Library Name: Altium Postgres.SVNDbLib
X101 X122 = 3569: Screw Block POLYAMID 3POL 15MM 15A; manufacturer: Lumberg; Database Table Name: altium.connector; state: approved; temperaturerange: -25 °C to +100 °C; Component Kind: Standard; componentlink1description: Datasheet; componentlink1url: \\fs1\GT-Daten\Datenbanken\AltiumDesigner\Library\Datasheets\Connectors\Lumberg_KRM.pdf; designator: X; distributor1link: http://de.farnell.com/lumberg/krm-03/klemme-leiterpl-polyamid-3pol-15mm/dp/1177876?Ntt=1177876; distributor1name: Farnell; distributor1orderno: 1177876; distributor2link: http://de.rs-online.com/web/p/klemmenleisten/2509027616/?searchTerm=KRM+03&relevancy-data=636F3D3126696E3D4931384E4272616E644D504E266C753D6465266D6D3D6D61746368616C6C26706D3D5E285C772B5B2D5C2E5C732F5D292B285C772B293F2426706F3D3526736E3D592673743D4B4559574F52445F4D554C54495F414C5048415F4E554D45524943267573743D4B524D2030332677633D4E4F4E4526; distributor2name: RS; distributor2orderno: 2509027616; id: 3569; manufacturer_pn: KRM 03; manufacturerlink: http://www.lumberg.com; Library Name: Altium Postgres.SVNDbLib
X102 X103 X105 X108 X113 X118 X121 = 1096: manufacturer: FischerElektronik; manufacturer_pn: SL 11 124 36Z; Database Table Name: altium.connector; state: approved; temperaturerange: -40 °C to +163 °C; Component Kind: Standard; componentlink1description: Datasheet; componentlink1url: \\fs1\GT-Daten\Datenbanken\AltiumDesigner\Library\Datasheets\PinHeader\Pin_Header_Fischer_2.54.pdf; Description: PinHeader 1x2; designator: X; distributor1name: Bürklin; distributor1orderno: 59F6448; id: 1096; manufacturerlink: http://www.fischerelektronik.de; Library Name: Altium Postgres.SVNDbLib
X104 X106 X107 X111 X112 X124 = 5361: Connecting Pad 0.8 mm 1.6 mm; Database Table Name: altium.connector; state: checked; designator: X; id: 5361; manufacturer: -; manufacturer_pn: -; manufacturerlink: -; temperaturerange: -; Library Name: Altium Postgres.SVNDbLib
X109 = 1100: manufacturer: FischerElektronik; manufacturer_pn: SL 11 124 36Z; Database Table Name: altium.connector; state: approved; temperaturerange: -40 °C to +163 °C; Component Kind: Standard; componentlink1description: Datasheet; componentlink1url: \\fs1\GT-Daten\Datenbanken\AltiumDesigner\Library\Datasheets\PinHeader\Pin_Header_Fischer_2.54.pdf; Description: PinHeader 1x6; designator: X; distributor1name: Bürklin; distributor1orderno: 59F6448; id: 1100; manufacturerlink: http://www.fischerelektronik.de; Library Name: Altium Postgres.SVNDbLib
X110 = 1098: manufacturer: FischerElektronik; manufacturer_pn: SL 11 124 36Z; Database Table Name: altium.connector; state: checked; temperaturerange: -40 °C to +163 °C; Component Kind: Standard; componentlink1description: Datasheet; componentlink1url: \\fs1\GT-Daten\Datenbanken\AltiumDesigner\Library\Datasheets\PinHeader\Pin_Header_Fischer_2.54.pdf; Description: PinHeader 1x4; designator: X; distributor1name: Bürklin; distributor1orderno: 59F6448; id: 1098; manufacturerlink: http://www.fischerelektronik.de; Library Name: Altium Postgres.SVNDbLib
